# Altium schematic sheet PowerSupplyHammondFrontPnlRev1b.SchDoc(PowerSupplyHammondFrontPnlRev1b)
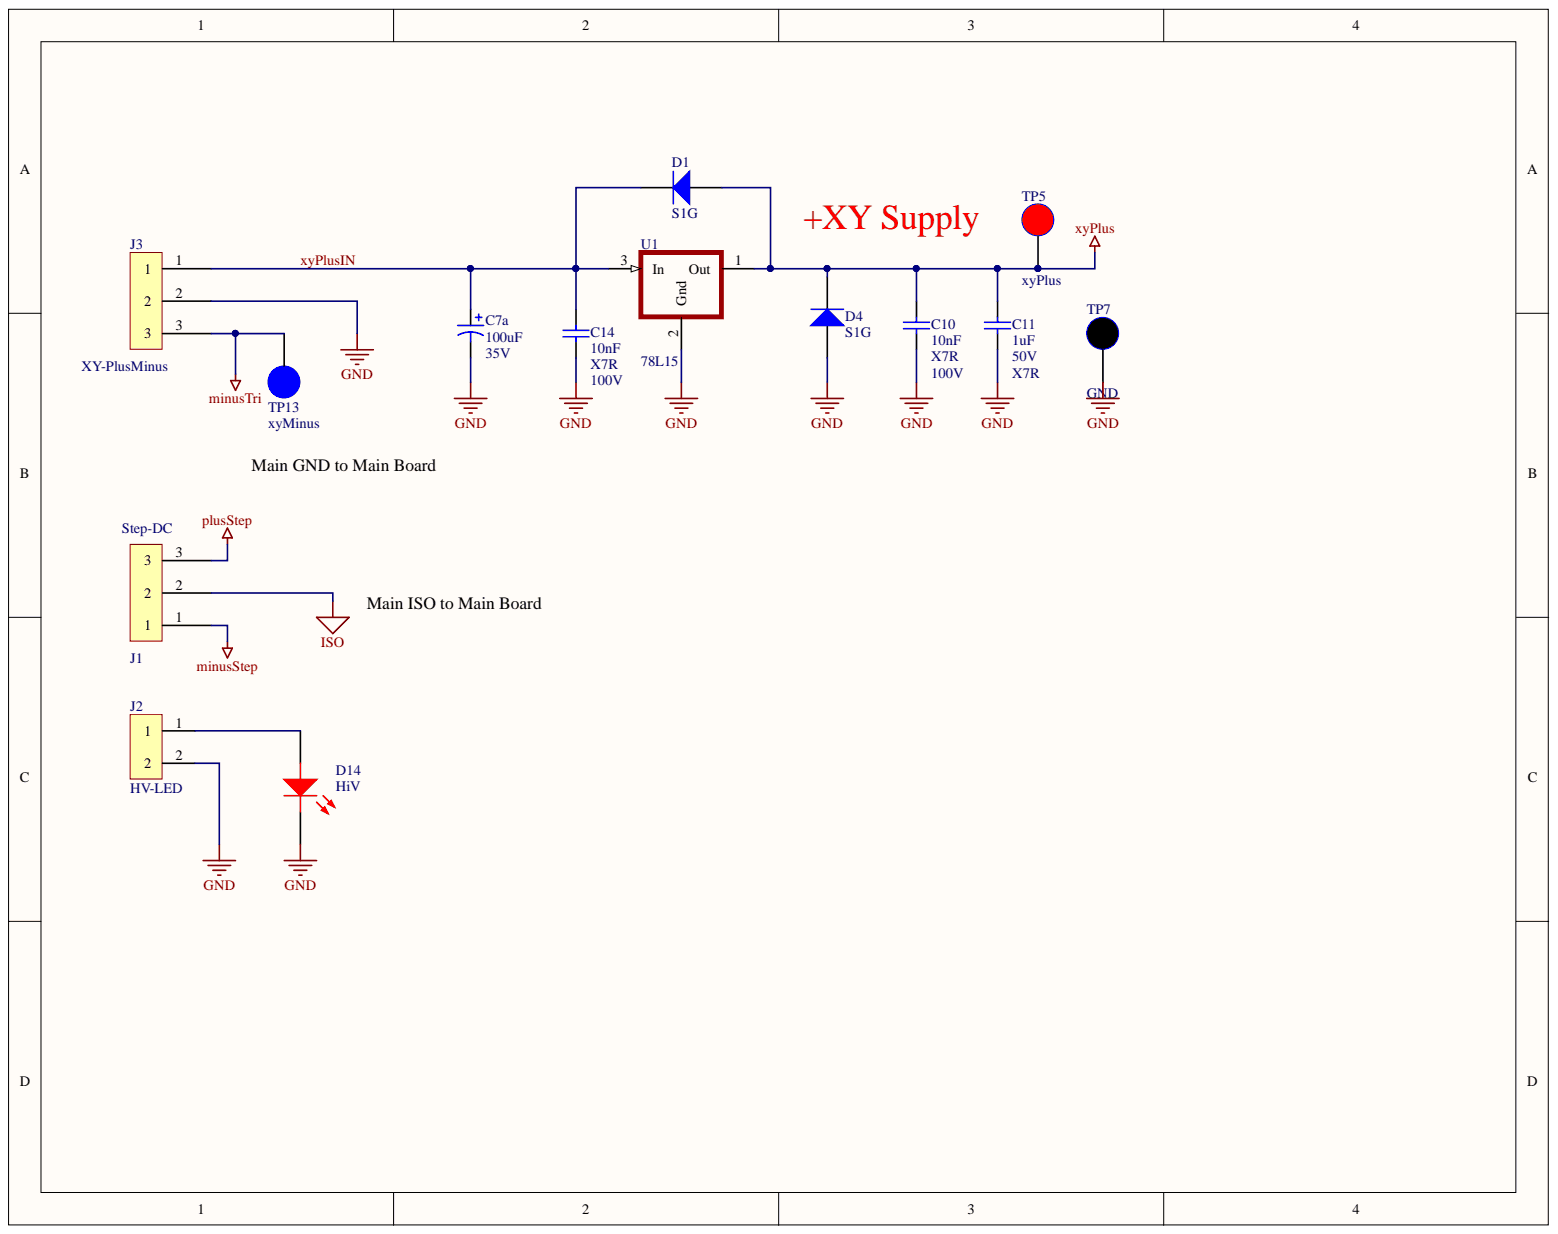
[diagram p0: PowerSupplyHammondFrontPnlRev1b.SchDoc]

## Parts
C7a  100uF  Voltage Rating: 35V  [CAPPR2.5-6.3X10]
C10  10nF  Voltage Rating (DC): 100V  Dielectric: X7R  [CAPC3216M]
C11  1uF  Voltage Rating (DC): 50V  Dielectric: X7R  [CAPC3216M]
C14  10nF  Voltage Rating (DC): 100V  Dielectric: X7R  [CAPC3216M]
D1  S1G  [SMA-D]
D4  S1G  [SMA-D]
D14  HiV  [LED-RED-3MM]
J1  Step-DC  [DTA-100-3]
J2  HV-LED  [DTA-100-2]
J3  XY-PlusMinus  [DTA-100-3]
TP5  xyPlus  [TP-.038]
TP7  GND  [TP-.038]
TP13  xyMinus  [TP-.038]
U1  78L15  [SOT89M]

## Nets
GND: C7a.2 C10.1 C11.2 C14.1 D4.1 D14.2 J2.2 J3.2 TP7.1 U1.2
ISO: J1.2
minusStep: J1.1
minusTri: J3.3 TP13.1
plusStep: J1.3
xyPlus: C10.2 C11.1 D1.1 D4.2 TP5.1 U1.1
xyPlusIN: C7a.1 C14.2 D1.2 J3.1 U1.3
NetD14_1: D14.1 J2.1
N00005:

## Library data of the parts
C7a = Cap Pol1: CAP ALUM 100UF 20% 35V THRU HOLE; Manufacturer Part Number: 35YXJ100M6.3X11; Manufacturer: Rubycon; Ripple Current: 400mA; Polarity: Polar; Life (Hours): 7000Hour; Leakage Current: 3uA; Lead Diameter: 0.5mm; Impedance: 220mR; Voltage: 35V; Value: 100uF; Tolerance: 20%; Termination: Radial; Supplier Part Number: 1189-2260-ND; Supplier: Digi-Key; Simulation: CAP; Signal Integrity: Cap Pol; Radiation Hardening: No; Packaging: Bulk; Package Quantity: 3000; Mount: Through Hole; Min Operating Temperature: -40°C; Max Operating Temperature: 105°C; Library Name: Miscellaneous Devices.IntLib; Lead Pitch: 2.5mm; Height - Seated (Max): 0.492inch; Height: 11mm; Diameter: 6.3mm; Component Kind: Standard; Case/Package: Radial; Capacitance: 100uF
C10 C14 = Cap: CAP CER 10NF 100V X7R 1206; Manufacturer Part Number: C1206C103K1RACTU; Manufacturer: KEMET; Tolerance: 10%; Dissipation Factor: 2.5%; Dielectric Material: Ceramic; Width: 0.063inch; Voltage Rating: 100V; Voltage: 100V; Value: 10nF; Thickness: 0.035inch; Termination: SMD/SMT; Supplier Part Number: 399-1236-6-ND; Supplier: Digi-Key; Simulation: CAP; Signal Integrity: Cap; Series: C; REACH SVHC: No SVHC; Radiation Hardening: No; Packaging: Tape and Reel; Package Quantity: 1; Number of Pins: 2; Mount: Surface Mount,PCB; Min Operating Temperature: -55°C; Max Operating Temperature: 125°C; Library Name: Miscellaneous Devices.IntLib; Length: 3.2mm; Height: 0.78mm; Depth: 1.6mm; Contact Plating: Tin,Matte; Construction: Flat; Component Kind: Standard; Case Code (Metric): 3216; Case Code (Imperial): 1206; Case/Package: 1206; Capacitance: 10nF
C11 = Cap: CAP CER 1UF 50V X7R 1206; Manufacturer Part Number: C1206C105K5RACTU; Manufacturer: KEMET; Tolerance: 10%; Dielectric Material: Ceramic; Width: 0.063inch; Voltage Rating: 50V; Voltage: 50V; Value: 1uF; Thickness: 0.043inch; Termination: SMD/SMT; Supplier Part Number: 399-8147-6-ND; Supplier: Digi-Key; Simulation: CAP; Signal Integrity: Cap; Series: C; REACH SVHC: No SVHC; Radiation Hardening: No; Packaging: Tape and Reel; Package Quantity: 1; Number of Pins: 2; Mount: Surface Mount; Min Operating Temperature: -55°C; Max Operating Temperature: 125°C; Library Name: Miscellaneous Devices.IntLib; Length: 3.2mm; Height: 1mm; Depth: 1.6mm; Construction: Flat; Component Kind: Standard; Case Code (Metric): 3216; Case Code (Imperial): 1206; Case/Package: 1206; Capacitance: 1uF
D1 D4 = Diode 1N4002: Diode Switching 400V 1A 2-Pin DO-214AC T/R; Manufacturer: ON Semiconductor / Fairchild; Reverse Recovery Time: 1.8us; Recovery Time: 1800ns; Power Dissipation: 1.4W; Polarity: Standard; Peak Reverse Current: 1uA; Peak Non-Repetitive Surge Current: 30A; Max Surge Current: 40A; Forward Voltage: 1.1V; Element Configuration: Single; Capacitance: 12pF; Width: 2.95mm; Weight: 0.106g; Voltage Rating (DC): 400V; Value: S1G; Supplier Part Number: S1GFSCT-ND; Supplier: Digi-Key; Simulation: 1N4002; Signal Integrity: 1N4002; Reverse Voltage: 400V; REACH SVHC: No SVHC; Radiation Hardening: No; Packaging: Tape and Reel; Package Quantity: 1; Output Current: 1A; Number of Pins: 2; Mount: Surface Mount; Min Operating Temperature: -55°C; Max Reverse Voltage (DC): 400V; Max Repetitive Reverse Voltage (Vrrm): 400V; Max Operating Temperature: 150°C; Max Forward Surge Current (Ifsm): 30A; Manufacturer Part Number: S1G; Library Name: Miscellaneous Devices.IntLib; Length: 4.75mm; Lead Free: Lead Free; Height: 2.2mm; Forward Current: 1A; Current Rating: 1A; Contact Plating: Tin,Matte; ComponentLink2URL: http://www.fairchildsemi.com/ds/1N%2F1N4001.pdf; ComponentLink2Description: Datasheet; ComponentLink1URL: http://www.fairchildsemi.com/; ComponentLink1Description: Manufacturer Link; Component Kind: Standard; Case/Package: SMA; Average Rectified Current: 1A
D14 = Red: LED RED DIFFUSED T-1 3/4 T/H; Manufacturer Part Number: 4304H1; Manufacturer: VCC CHML; Wavelength: 650nm; Viewing Angle: 65°; Value: RED LED; Test Current: 10mA; Power Dissipation: 60mW; Number of Elements: 1; Luminous Intensity: 6.3mcd; Lens Style: Dome,Diffused,Round; Lens Size: 5mm; Forward Voltage: 2V; Dominant Wavelength: 640nm; Supplier Part Number: L71532-ND; Supplier: Digi-Key; REACH SVHC: Unknown; Packaging: Bulk; Package Quantity: 1000; Number of Pins: 2; Mount: Through Hole; Library Name: My_LED.SchLib; Lens Transparency: Diffused; Lens Color: Red; Length: 9.65mm; Lead Free: Lead Free; Illumination Color: Red; Height: 8.99mm; Forward Current: 10mA; Component Kind: Standard; Color: Red; Case/Package: Radial
J1 J3 = 3-Pin: CONN HEADER VERT 3POS 2.54MM; Manufacturer: TE Connectivity; Voltage Rating (AC): 250V; Value: 640456-3; Tail Length: 0.14inch; Strain Relief: No (Without); Plating Thickness: 3.81um; PCB Thickness: 0.063inch; PCB Mount Retention: Without; PCB Mounting Orientation: Vertical; Number of Positions: 3; Mating Connector Lock: Yes (With); Gender: Male; Current Rating: 5A; Contact Style: Straight; Contact Resistance: 6mR; Contact Post Length: 3.56mm; Connector Type: Connector,Pin,Plug,Header; Connector System: Wire-to-Board; Circuit Application: Signal; Approvals: CSA; Voltage Rating: 250V; Termination: Solder; Supplier Part Number: A19470-ND; Supplier: Digi-Key; Shrouded: No (Without); Sealable: No (Without); REACH SVHC: Unknown; Radiation Hardening: No; Pitch: 2.54mm; PCB Mount Alignment: No (Without); Panel Mount Retention: No (Without); Packaging: Bulk; Package Quantity: 5000; Orientation: Straight; Number of Rows: 1; Number of Contacts: 3; Mounting Ears: No (Without); Mount: Through Hole; Min Operating Temperature: -67°C; Max Voltage Rating (AC): 250V; Max Operating Temperature: 221°C; Mating Retention: No (Without); Mating Alignment: No (Without); Manufacturer Part Number: 640456-3; Library Name: MY_CONNECTORS.SchLib; Length: 0.4inch; Lead Free: Lead Free; Insulation Material: Thermoplastic; Insulation Color: Natural; Housing Material: Polyester; Housing Color: Natural; Height: 7.87mm; Flammability Rating: UL94 V-0; Fastening Type: Friction; ELV: Compliant; Depth: 5.72mm; Contact Shape: Square; Contact Retention: No (Without); Contact Plating: Tin; Contact Mating Length: 7.49mm; Contact Material: Copper Alloy; Contact Gender: Male; Contact Current Rating: 5A; Component Kind: Standard; Color: Beige,White; Backwall/Post Interruptions: No (Without)
J2 = 2-Pin: CONN HEADER VERT 2POS 2.54MM; Manufacturer: TE Connectivity; Working Voltage: 250V; Value: 640456-2; Tail Length: 0.14inch; Strain Relief: No (Without); Plating Thickness: 3.81um; PCB Thickness: 0.063inch; PCB Mount Retention: Without; PCB Mounting Orientation: Vertical; Number of Positions: 2; Mating Connector Lock: Yes (With); Gender: Male; Current Rating: 5A; Contact Style: Straight; Contact Resistance: 6mR; Contact Post Length: 3.56mm; Connector Type: Connector,Pin,Plug,Header; Connector System: Wire-to-Board; Circuit Application: Signal; Approvals: CSA; Voltage Rating (AC): 250V; Voltage Rating: 250V; Termination: Solder; Supplier Part Number: A1921-ND; Supplier: Digi-Key; Shrouded: No (Without); Sealable: No (Without); REACH SVHC: No SVHC; Radiation Hardening: No; Pitch: 2.54mm; PCB Mount Alignment: No (Without); Panel Mount Retention: No (Without); Packaging: Bulk; Package Quantity: 5000; Orientation: Straight; Number of Rows: 1; Number of Poles: 2; Number of Contacts: 2; Mounting Ears: No (Without); Mount: Through Hole; Min Operating Temperature: -67°C; Max Voltage Rating (AC): 250V; Max Operating Temperature: 221°C; Mating Retention: No (Without); Mating Alignment: No (Without); Manufacturer Part Number: 640456-2; Library Name: MY_CONNECTORS.SchLib; Length: 7.62mm; Lead Pitch: 2.54mm; Lead Free: Lead Free; Housing Material: Polyester; Housing Color: Natural; Height: 7.87mm; Flammability Rating: UL94 V-0; Fastening Type: Friction; ELV: Compliant; Depth: 5.72mm; Contact Shape: Square; Contact Retention: No (Without); Contact Plating: Tin; Contact Mating Length: 7.49mm; Contact Material: Copper Alloy; Contact Gender: Male; Contact Current Rating: 5A; Component Kind: Standard; Color: Natural,White,Beige; Backwall/Post Interruptions: No (Without)
TP5 = TP-Round-Red: TP Thru-Hole; Manufacturer Part Number: Gold; Manufacturer: UW; Value: TP Thru-Hole; Supplier Part Number: Gold; Supplier: UW; Library Name: MY_TP.SchLib; Component Kind: Standard
TP7 = TP-Round-Black: TP Thru-Hole; Manufacturer Part Number: Gold; Manufacturer: UW; Value: TP Thru-Hole; Supplier Part Number: Gold; Supplier: UW; Library Name: MY_TP.SchLib; Component Kind: Standard
TP13 = TP-Round-Blue: TP Thru-Hole; Manufacturer Part Number: Gold; Manufacturer: UW; Value: TP Thru-Hole; Supplier Part Number: Gold; Supplier: UW; Library Name: MY_TP.SchLib; Component Kind: Standard
U1 = 78L00-3PIN: UA78L15ACPK 15V  0.1A SOT-89-3; Manufacturer: Texas Instruments; Quiescent Current: 6mA; Power Supply Rejection Ratio (PSRR): 39dB; Polarity: Positive; Output Voltage Accuracy: 5%; Output Type: Fixed; Output Current: 100mA; Number of Regulators: 1; Min Input Voltage: 17.5V; Max Input Voltage: 30V; Dropout Voltage: 1.7V; Width: 2.5mm; Value: 78L15; Supplier Part Number: 296-12002-1-ND; Supplier: Digi-Key; REACH SVHC: No SVHC; Radiation Hardening: No; Packaging: Tape and Reel; Package Quantity: 1; Output Voltage: 15V; Number of Pins: 3; Number of Outputs: 1; Nominal Output Voltage: 15V; Min Output Voltage: 15V; Min Operating Temperature: -40°C; Max Output Voltage: 15V; Max Output Current: 100mA; Max Operating Temperature: 125°C; Manufacturer Part Number: UA78L15ACPK; Length: 4.5mm; Lead Free: Lead Free; Height: 1.5mm; Contact Plating: Tin,Matte; Component Kind: Standard; Case/Package: SOT-89; Accuracy: 5%; Library Name: MY_Vregs.SchLib
